# Altium schematic sheet FlashLight_Circuit.SchDoc(FlashLight_Circuit)
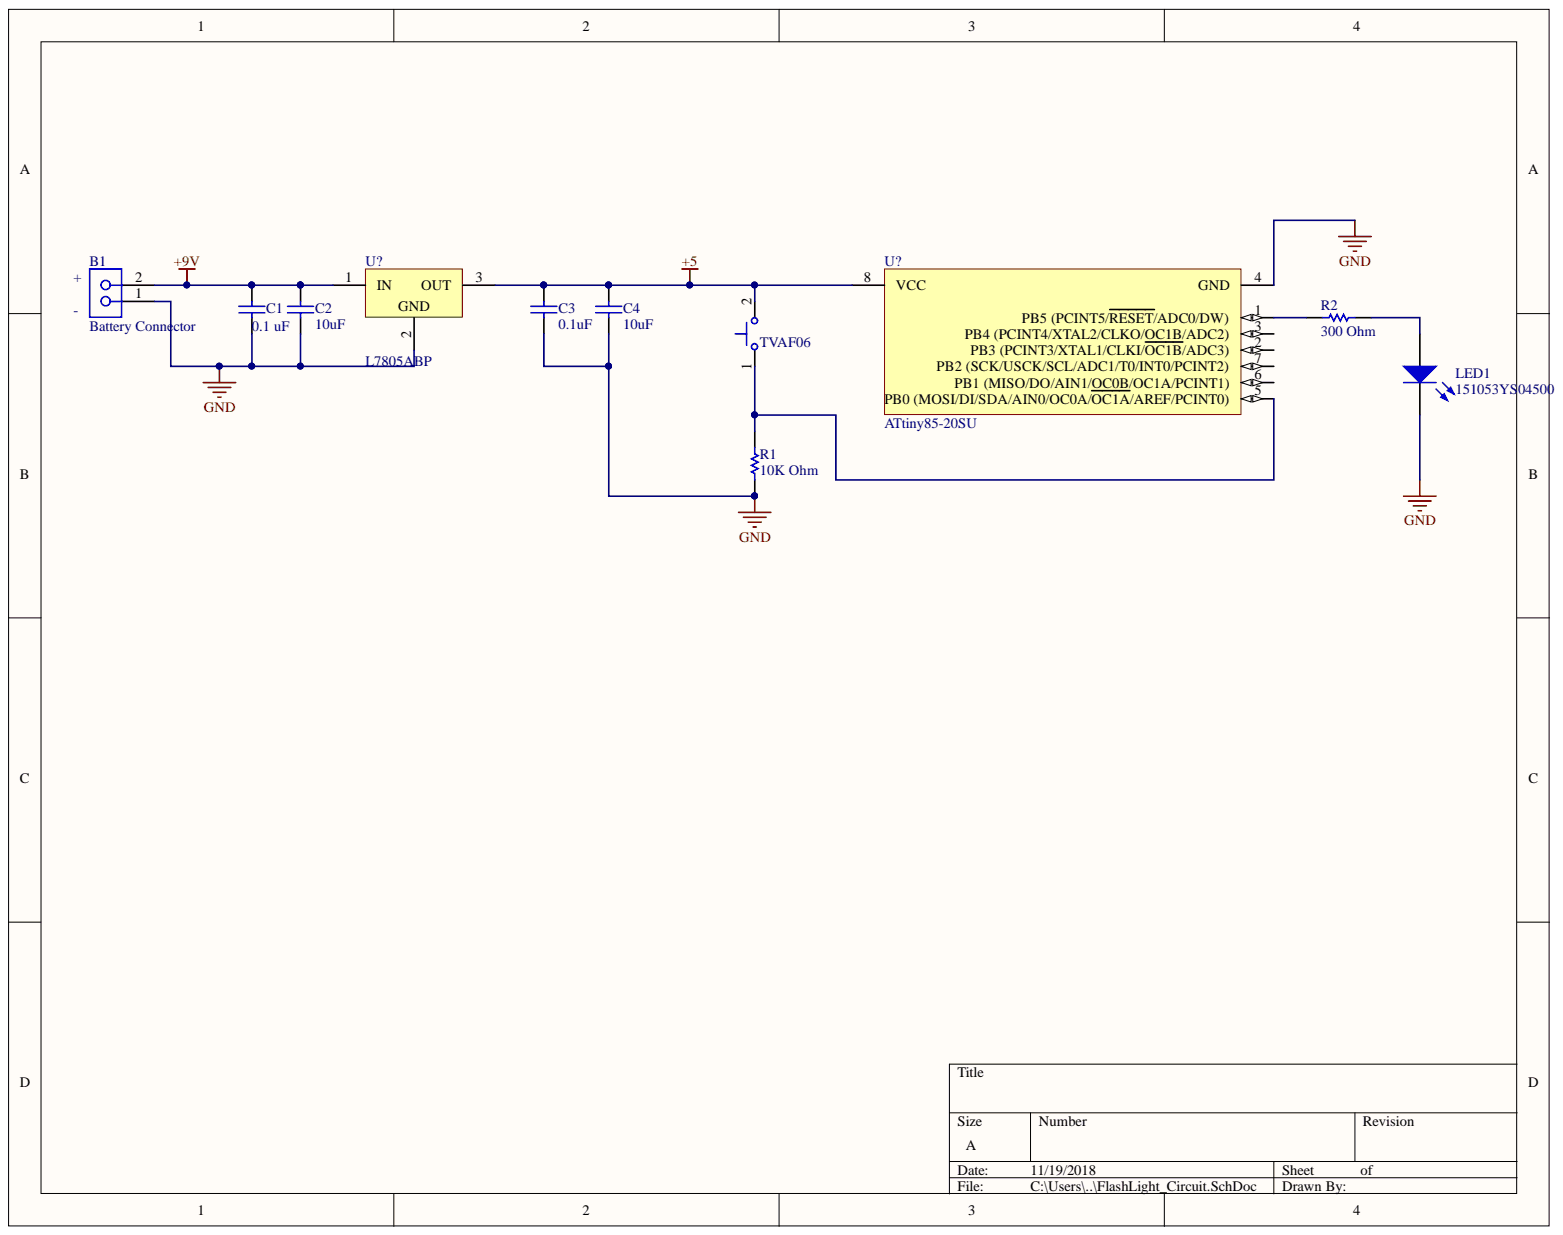
[diagram p0: FlashLight_Circuit.SchDoc]

## Parts
B1  Battery Connector  [SMTC-PHF-102-01-X-S-002]
C1  0.1 uF  [CAPC2013X135X45NL10T25]
C2  10uF  [CAPC2013X135X45NL10T25]
C3  0.1uF  [CAPC2013X135X45NL10T25]
C4  10uF  [CAPC2013X135X45NL10T25]
LED1  151053YS04500  [Type 5mm]
R1  10K Ohm  [RESC2013X60X35ML10T20]
R2  300 Ohm  [RESC2013X60X35ML10T20]
U?  ATtiny85-20SU  [8S2_M]
U?  L7805ABP  [TO220FP]
  TVAF06  [JNFU-TVAF06_V]

## Nets
+5: C3.2 C4.2 U?.3 U?.8(VCC) .2
+9V: B1.2 C1.2 C2.2 U?.1
GND: B1.1 C1.1 C2.1 C3.1 C4.1 LED1.1 R1.1 U?.2 U?.4(GND)
Net_1: R1.2 U?.5(PB0_(MOSI/DI/SDA/AIN0/OC0A/OC1A/AREF/PCINT0)) .1
NetLED1_2: LED1.2 R2.2
NetR2_1: R2.1 U?.1

Not connected: U? 6(PB1_(MISO/DO/AIN1/OC0B/OC1A/PCINT1)) 7(PB2_(SCK/USCK/SCL/ADC1/T0/INT0/PCINT2))

## Library data of the parts
B1 = CMP-1023-00103-1: Press Fit Socket, Through-hole, Vertical, -55 to 125 degC, 2.54 mm Pitch, 2-Pin, Female, RoHS; PartNumber: PHF-102-01-L-S-002; Manufacturer: Samtec; Pitch: 2.54 mm; Temperature Range: -55 to 125 degC; RoHS: True; PackageVersion: F, 9/2009; PackageReference: PHF-102-01-X-S-002; PackageDescription: .100 Press Fit Socket Assembly; Orientation: Vertical; Mounting Technology: Through-hole; Library Name: Altium Content Vault; DatasheetVersion: F-214; ComponentLink3URL: http://www.samtec.com/documents/webfiles/cpdf/PHF-1XX-XX-X-X-XXX-MKT.pdf; ComponentLink3Description: Package Specification; ComponentLink2URL: http://www.samtec.com/documents/webfiles/pdf/phf.pdf; ComponentLink2Description: Datasheet; ComponentLink1URL: http://www.samtec.com; ComponentLink1Description: Manufacturer URL; Component Kind: Standard
C1-C4 = CMP-2007-03551-1: Part Number: GRM21BR71A105KA01L; Manufacturer: Murata; Library Name: Altium Content Vault; Component Kind: Standard
LED1 = CMP-1477-00001-1: THT LED round mono-color waterclear, WL-TMRW, Yellow; Manufacturer: Wuerth Elektronik; PartNumber: 151053YS04500; PackageReference: Type 5mm; Library Name: Altium Content Vault; Emitting color: Yellow; ComponentLink2URL: http://katalog.we-online.de/pbs/datasheet/7448042001.pdfhttp://katalog.we-online.de/pbs/datasheet/7448042001.pdfhttp://katalog.we-online.de/pbs/datasheet/7448042001.pdfhttp://katalog.we-online.de/pbs/datasheet/151053YS04500.pdf; ComponentLink2Description: Datasheet; ComponentLink1URL: http://www.we-online.com; ComponentLink1Description: Manufacturer URL; Component Kind: Standard
R1 R2 = CMP-2001-01354-1: Part Number: RC0805JR-07200RL; Manufacturer: Yageo; Library Name: Altium Content Vault; Component Kind: Standard
U? = CMP-0093-00173-2: 8-bit AVR Microcontroller, 20MHz, 2.7-5.5V, 8K Flash, 512 Bytes EEPROM, 512 Bytes SRAM, Industrial Grade (-40°C to 85°C), 8-Lead SOIC, Pb-Free; RevisionNote: DatasheetVersion updated. URLs added.; PackageVersion: revF, Apr-2008; PackageReference: 8S2; Library Name: Altium Content Vault; DatasheetVersion: revN, Apr-2011; ComponentLink3URL: http://www.atmel.com/dyn/resources/prod_documents/2586S.pdf; ComponentLink3Description: ATtiny25/45/85 Summary; ComponentLink2URL: http://www.atmel.com/dyn/resources/prod_documents/doc2586.pdf; ComponentLink2Description: ATtiny25/45/85 Datasheet; ComponentLink1URL: http://www.atmel.com/; ComponentLink1Description: Manufacturer URL; Component Kind: Standard
U? = CMP-0244-00479-1: Positive Voltage Regulator, 5V, 3-Pin TO-220FP; PackageVersion: 2011; PackageReference: TO220FP; PackageDescription: TO, Thru-Hole, Vertical, Heatsink Mounted; 3 In-Line Leads; Pitch 2.54 mm; Library Name: Altium Content Vault; DatasheetVersion: 16/11/2011; ComponentLink2URL: http://www.st.com/internet/com/TECHNICAL_RESOURCES/TECHNICAL_LITERATURE/DATASHEET/CD00000444.pdf; ComponentLink2Description: Datasheet; ComponentLink1URL: http://www.st.com; ComponentLink1Description: Manufacturer URL; Component Kind: Standard
 = CMP-0587-00001-1: Switch, SPST Push Button, 50 mA, 12 V DC, SMD, -30 to 85 degC, RoHS; Manufacturer: Jianfu; Travel: 0.13 +/- 0.05 mm; Rating: 50 mA. 12 V DC; Lifetime: 100000 Cycles min; Insulation Resistance: 100 MW min. 100 V DC; Dielectric Strength: 250 V AC for 1 min; Contact Resistance: 100 mW max; RoHS: TRUE; PartNumber: TVAF06; PackageReference: TVAF06; PackageDescription: 2-Pin Switch, Push Button, SMD, Body 2.9 x 3.9 mm; Operating Temperature: -30 to 85 degC; Mounting Technology: Surface Mount; Library Name: Altium Content Vault; ComponentLink2URL: http://en.jian-fu.com/product-22.html; ComponentLink2Description: Datasheet; ComponentLink1URL: http://www.jian-fu.com/; ComponentLink1Description: Manufacturer URL; Component Kind: Standard
